# Altium schematic sheet PowerSupply.SchDoc
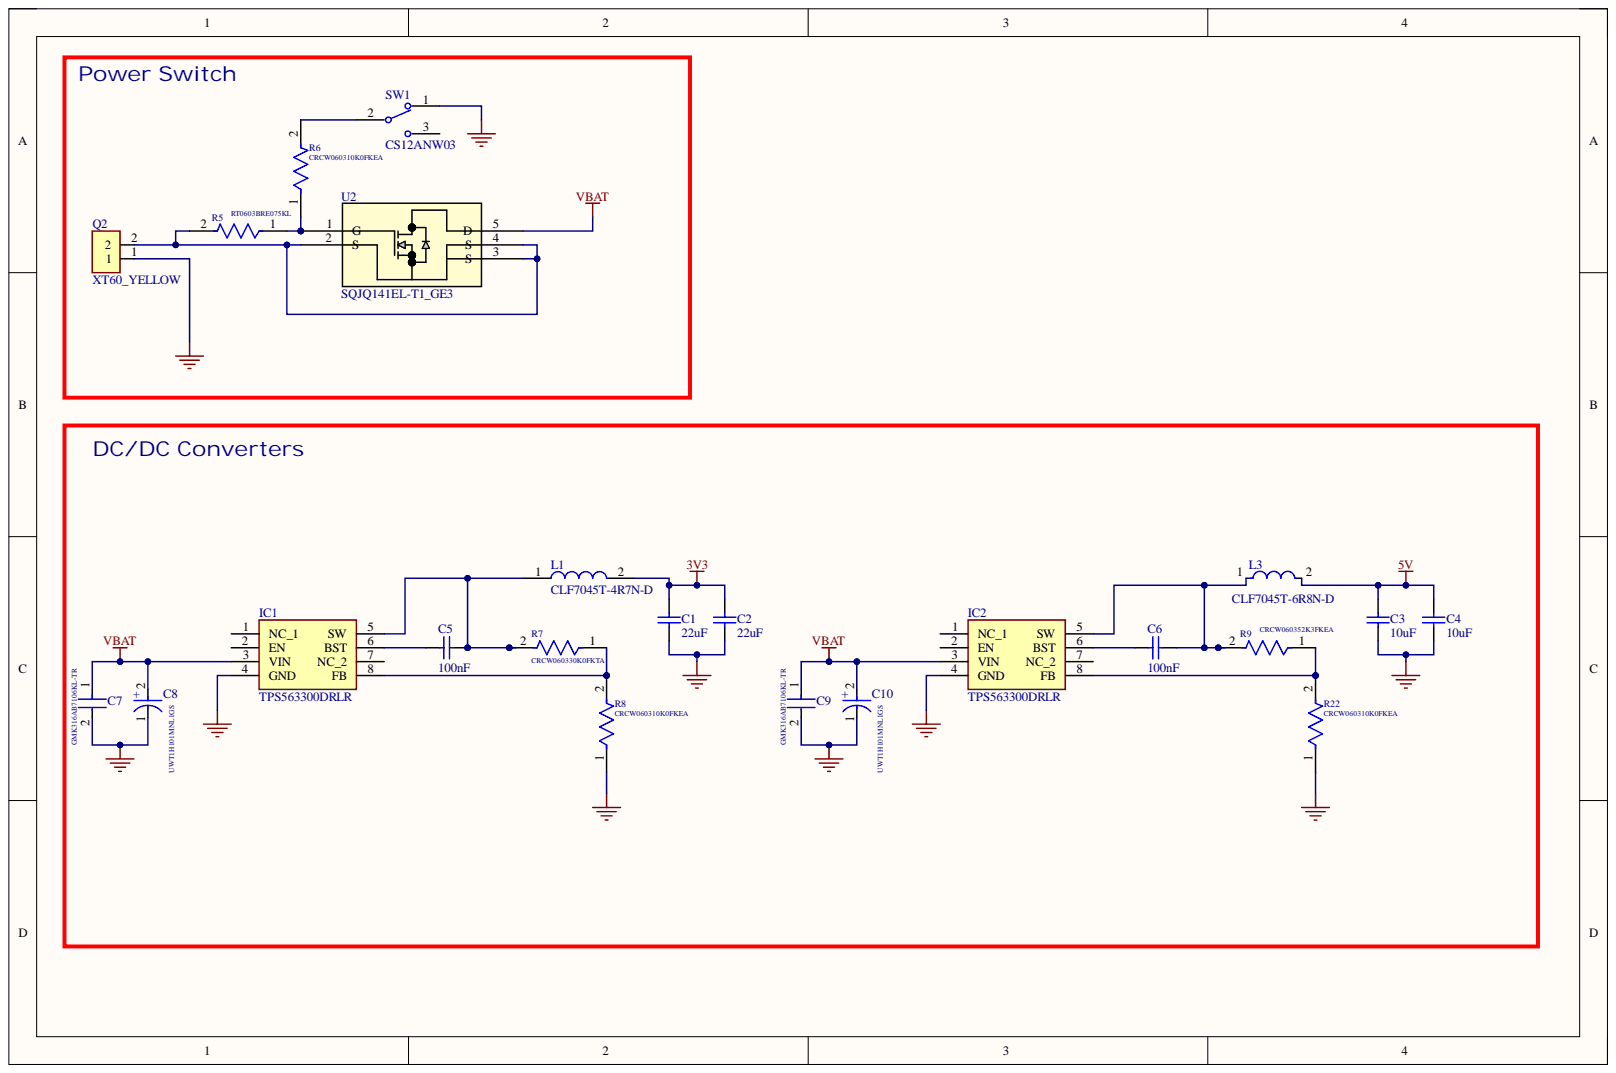
[diagram p0: PowerSupply.SchDoc]

## Parts
C1  22uF  [CAPC0805(2012)155_L]
C2  22uF  [CAPC0805(2012)155_L]
C3  10uF  [CAPC0805(2012)155_L]
C4  10uF  [CAPC0805(2012)155_L]
C5  100nF  [CAPC0805(2012)155_L]
C6  100nF  [CAPC0805(2012)155_L]
C7  GMK316AB7106KL-TR  [FP-1206-L_3_2_0_2-W_1_6_0-IPC_C]
C8  UWT1H101MNL1GS  [FP-UWT1H101MNL1GS-MFG]
C9  GMK316AB7106KL-TR  [FP-1206-L_3_2_0_2-W_1_6_0-IPC_C]
C10  UWT1H101MNL1GS  [FP-UWT1H101MNL1GS-MFG]
IC1  TPS563300DRLR  [SOTFL50P160X60-8N]
IC2  TPS563300DRLR  [SOTFL50P160X60-8N]
L1  CLF7045T-4R7N-D  [CLF7045-D]
L3  CLF7045T-6R8N-D  [FP-CLF7045-MFG]
Q2  XT60_YELLOW  [AMASS_XT60PB-M]
R5  RT0603BRE075KL  [FP-CRCW0603-e3-IPC_A]
R6  CRCW060310K0FKEA  [FP-CRCW0603-e3-IPC_A]
R7  CRCW060330K0FKTA  [FP-CRCW0603-e3-IPC_A]
R8  CRCW060310K0FKEA  [FP-CRCW0603-e3-IPC_A]
R9  CRCW060352K3FKEA  [FP-CRCW0603-e3-IPC_A]
R22  CRCW060310K0FKEA  [FP-CRCW0603-e3-IPC_A]
SW1  CS12ANW03  [FP-CS12ANW03-MFG]
U2  SQJQ141EL-T1_GE3  [PowerPAK_8x8L_BWL-2_VIS]

## Nets
3V3: C1.2 C2.2 L1.2
5V: C3.2 C4.2 L3.2
GND: C1.1 C2.1 C3.1 C4.1 C7.2 C8.1 C9.2 C10.1 IC1.4(GND) IC2.4(GND) Q2.1 R8.1 R22.1 SW1.1
VBAT: C7.1 C8.2 C9.1 C10.2 IC1.3(VIN) IC2.3(VIN) U2.5(D)
NetC5_1: C5.1 IC1.5(SW) L1.1 R7.2
NetC5_2: C5.2 IC1.6(BST)
NetC6_1: C6.1 IC2.5(SW) L3.1 R9.2
NetC6_2: C6.2 IC2.6(BST)
NetIC1_8: IC1.8(FB) R7.1 R8.2
NetIC2_8: IC2.8(FB) R9.1 R22.2
NetQ2_2: Q2.2 R5.2 U2.2(S) U2.3(S) U2.4(S)
NetR5_1: R5.1 R6.1 U2.1(G)
NetR6_2: R6.2 SW1.2

Not connected: IC1 1(NC_1) 2(EN) 7(NC_2); IC2 1(NC_1) 2(EN) 7(NC_2); SW1 3

## Library data of the parts
C1-C6 = CMP-1036-04916-1: CAP 10uF 6.3V ±10% 0805 (2012 Metric) Thickness 1.55mm SMD; Case-EIA: 0805; Case-Metric: 2012; Tolerance: Â±10%; Component Kind: Standard; Library Name: Altium Content Vault; Max Thickness: 1.55 mm; Rated Voltage: 6.3 V; Technology: SMT; Value: 10uF
C7 C9 = CMP-2000-06324-3: None; Capacitance: 10uF; Dielectric: X7R; Tolerance: 10%; Voltage Rating: 35V; Case Code (Imperial): 1206; Case Code (Metric): 3216; Case/Package: 1206; Component Kind: Standard; Height: 1.6mm; Length: 0.126inch; Library Name: Altium Content Vault; Max Operating Temperature: 125°C; Min Operating Temperature: -55°C; Mount: Surface Mount; Package Quantity: 2000; Packaging: Tape and Reel; REACH SVHC: No SVHC; Resistor Type: Ceramic; RoHS Compliant: Yes; Series: M; Termination: SMD/SMT; Thickness: 0.071inch; Voltage Rating (DC): 35V; Width: 1.6mm
C8 C10 = CMP-2000-07373-2: CAP ALUM 100UF 20% 50V SMD; Dielectric Material: Aluminium; Dissipation Factor: 0.14%; Leakage Current: 3uA; Life (Hours): 1000Hour; Ripple Current: 140mA; Capacitance: 100uF; Case/Package: Radial; Component Kind: Standard; Construction: Cylindrical; Diameter: 8mm; Height: 10mm; Height - Seated (Max): 0.413inch; Lead Free: Lead Free; Length: 10mm; Library Name: Altium Content Vault; Max Operating Temperature: 105°C; Min Operating Temperature: -55°C; Mount: Surface Mount; Number of Pins: 2; Package Quantity: 500; Packaging: Tape and Reel; Radiation Hardening: No; REACH SVHC: No SVHC; Ripple Current (AC): 140mA; RoHS Compliant: Yes; Series: WT; Termination: SMD/SMT; Tolerance: 20%; Voltage: 50V; Voltage Rating: 50V; Voltage Rating (DC): 50V
IC1 IC2 = TPS563300DRLR: Integrated Circuit; Manufacturer_Name: Texas Instruments; Component Kind: Standard; Datasheet Link: https://www.ti.com/lit/ds/symlink/tps563300.pdf?ts=1666035990155&ref_url=https%253A%252F%252Fwww.ti.com%252Fproduct%252FTPS563300; Height: 0.6mm; Manufacturer_Part_Number: TPS563300DRLR; Mouser Part Number: 595-TPS563300DRLR; Mouser Price/Stock: https://www.mouser.co.uk/ProductDetail/Texas-Instruments/TPS563300DRLR?qs=vvQtp7zwQdMDxJAw0dSlAg%3D%3D; Library Name: roboime.SchLib
L1 = CLF7045T-4R7N-D: Inductor; Manufacturer_Name: TDK; Arrow Part Number: CLF7045T-4R7N-D; Arrow Price/Stock: https://www.arrow.com/en/products/clf7045t-4r7n-d/tdk; Component Kind: Standard; Datasheet Link: https://product.tdk.com/system/files/dam/doc/product/inductor/inductor/smd/wdcatalog/withdrawn_inductor_commercial_power_clf7045_en.pdf; Height: 4.8mm; Manufacturer_Part_Number: CLF7045T-4R7N-D; Mouser Part Number: 810-CLF7045T-4R7N-D; Mouser Price/Stock: https://www.mouser.co.uk/ProductDetail/TDK/CLF7045T-4R7N-D?qs=ilIqL0%2F%2FoDU8gjMEHwFGUQ%3D%3D; Library Name: roboime.SchLib
L3 = CMP-08253-000023-1: FIXED IND 6.8UH 3A 27 MOHM SMD; Manufacturer: TDK; Automotive Grade: Grade 0; Core Material: Ferrite; Series Resistance: 35.1mΩ; Shielding: true; Test Frequency: 100kHz; Tolerance: 30%; Automotive: Yes; Category: Ind; Component Kind: Standard; ComponentLink2Description: Datasheet; ComponentLink2URL: https://product.tdk.com/en/search/inductor/inductor/smd/info/print_pdf; Composition: Wirewound; Device Class L1: Passive Components; Device Class L2: Inductors; Device Class L3: Fixed Inductors; Digikey Description: FIXED IND 6.8UH 3A 27 MOHM SMD; Height: 4.8mm; Inductance: 6.8uH; Lead Free: Yes; Library Name: Altium Content Vault; Manufacturer Part Number: CLF7045T-6R8N-D; Mouser Description: Inductor Power Shielded Wirewound 6.8uH 30% 100KHz Ferrite 3A 35.1mOhm DCR Automotive T/R; Octopart: https://octopart.com/clf7045t-6r8n-d-tdk-27590387; Package: CLF7045; Rms Current: 3A; RoHS: TRUE; Temperature: -40°C to +150°C; Temperature Range High: +150°C; Temperature Range Low: -40°C; Value: 6.8uH
Q2 = XT60_YELLOW: Power connector; 65A; PIN: 2; Colour: yellow; MF: Pololu; MP: XT60 YELLOW; Availability: Not in stock; Check_prices: https://www.snapeda.com/parts/XT60%20YELLOW/Pololu/view-part/?ref=eda; Component Kind: Standard; Package: None; Price: None; SnapEDA_Link: https://www.snapeda.com/parts/XT60%20YELLOW/Pololu/view-part/?ref=snap; Library Name: roboime.SchLib
R5-R9 R22 = CMP-2000-03845-2: RES Thick Film, 10Ω, 1%, 0.1W, 100ppm/°C, 0603; Max Power Dissipation: 100mW; Resistance: 10R; Voltage Rating: 75V; Case Code (Imperial): 0603; Case Code (Metric): 1608; Case/Package: 0603; Component Kind: Standard; Composition: Thick Film; Contact Plating: Tin; Depth: 0.85mm; Features: Automotive AEC-Q200; Height: 0.45mm; Lead Free: Lead Free; Length: 1.55mm; Library Name: Altium Content Vault; Max Operating Temperature: 155°C; Military Standard: Not; Min Operating Temperature: -55°C; Mount: Surface Mount; Number of Pins: 2; Number of Terminations: 2; Package Quantity: 10; Packaging: Tape and Reel; Power Rating: 100mW; Temperature Coefficient: 100ppm/°C; Termination: SMD/SMT; Tolerance: 1%; Voltage Rating (DC): 75V; Weight: 7.1E-05oz; Width: 0.033inch
SW1 = CMP-31746-000002-1: SWITCH SLIDE SPDT 3A 125V; Actuator Length: 5.1mm; Actuator Material: Nylon; Actuator Type: Standard; Contact Style: Non-Shorting; Electrical Life: 20000Cycles; Illumination Type: Non-Illuminated; Max Voltage Rating (AC): 250V; Mechanical Life: 50000Cycles; Switch Function: On-On; Switch Travel: 2.2mm; Component Kind: Standard; Contact Current Rating: 3A; Contact Material: Copper; Contact Plating: Silver; Current Rating: 3A; Depth: 7.5mm; Flammability Rating: UL94 V-0; Lead Free: Lead Free; Length: 14mm; Library Name: Altium Content Vault; Max Current Rating: 3A; Max Operating Temperature: 85°C; Min Operating Temperature: -30°C; Mount: Through Hole; Orientation: Vertical; Package Quantity: 1; Packaging: Tray; Primary Type: SWITCH; Reach SVHC Compliant: Yes; RoHS Compliant: Yes; Series: CS; Termination: PC Pin; Throw Configuration: SPDT; Voltage Rating (AC): 125V
U2 = SQJQ141EL-T1_GE3: No Description Available; Mfr_Name: Vishay; Component Kind: Standard; Manufacturer_Part_Number: SQJQ141EL-T1_GE3; RefDes: RefDes; Type: DEV; Copyright: Copyright (C) 2023 Ultra Librarian. All rights reserved.; Library Name: roboime.SchLib
